# Altium schematic sheet Transductores_Presion.SchDoc(Transductores_Presion)
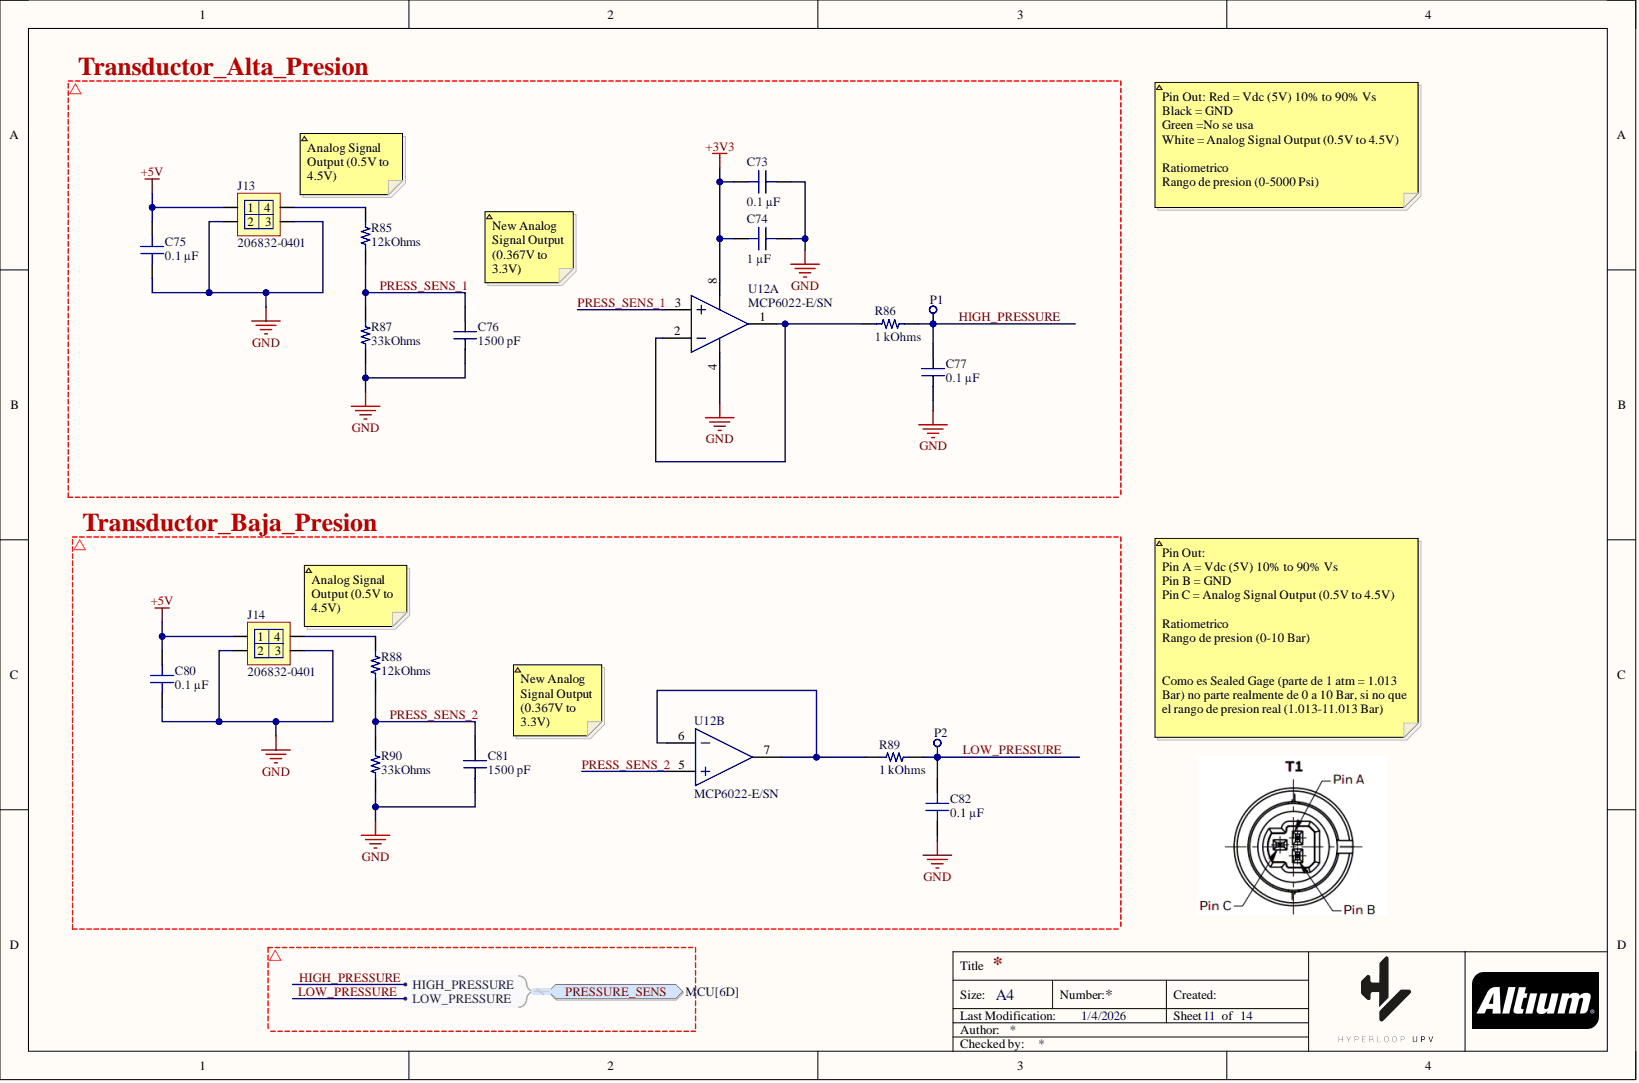
[diagram p0: Transductores_Presion.SchDoc]

## Parts
C73  0.1 µF
C74  1 µF
C75  0.1 µF
C76  1500 pF
C77  0.1 µF
C80  0.1 µF
C81  1500 pF
C82  0.1 µF
J13  206832-0401
J14  206832-0401
P1  Test point 1.2mm  (value not drawn)
P2  Test point 1.2mm  (value not drawn)
R85  12kOhms
R86  1 kOhms
R87  33kOhms
R88  12kOhms
R89  1 kOhms
R90  33kOhms
U12  MCP6022-E/SN  (parts A,B)

## Ports
PRESSURE_SENS, PRESSURE_SENS.HIGH_PRESSURE, PRESSURE_SENS.LOW_PRESSURE

## Nets
+3V3: C73.2 C74.1 U12.8
+5V: C75.2 C80.2 J13.1 J14.1
GND: C73.1 C74.2 C75.1 C76.1 C77.1 C80.1 C81.1 C82.1 J13.2 J13.3 J14.2 J14.3 R87.1 R90.1 U12.4
HIGH_PRESSURE: C77.2 P1.1 R86.2 ; port PRESSURE_SENS
LOW_PRESSURE: C82.2 P2.1 R89.2 ; port PRESSURE_SENS
PRESS_SENS_1: C76.2 R85.1 R87.2 U12.3
PRESS_SENS_2: C81.2 R88.1 R90.2 U12.5
NetJ13_4: J13.4 R85.2
NetJ14_4: J14.4 R88.2
NetR86_1: R86.1 U12.1 U12.2
NetR89_1: R89.1 U12.6 U12.7

## Library data of the parts
C73 C75 C80 = C0603X104K050T: CAP CER 0.1UF 50V X7R 0603; manufacturer: Holy Stone Enterprise Co., Ltd.; Database Table Name: Capacitors - Ceramic - 0603; Tolerance: ±10%; Applications: SMPS Filtering; Capacitance: 0.1 µF; Component Kind: Standard; componentlink1description: Datasheet; componentlink1url: https://www.holystonecaps.com/PDF/201612051329340.2017%20NCC%20Series.pdf; componentlink2description: DigiKey Link; componentlink2url: https://www.digikey.com/en/products/detail/holy-stone-enterprise-co-ltd/C0603X104K050T/16894915; Device Package: 0603 (1608 Metric); lastupdated: 2/9/2024 8:09:56 PM; Library Name: Celestial Altium Library - altium_library.DbLib; Lifecycle Status: Active; Minimum Order: 1; Mounting Type: Surface Mount, MLCC; Operating Temperature: -55°C ~ 125°C; Package / Case: 0603 (1608 Metric); packaging: TapeAndReel; Part Number: C0603X104K050T; Part Status: Active; partid: 35023; price: 0.01; series: MVC; Size / Dimension: 0.063" L x 0.031" W (1.60mm x 0.80mm); Supplier 1: DigiKey; Supplier Part Number 1: 4587-C0603X104K050TCT-ND; Temperature Coefficient: X7R; Thickness (Max): 0.039" (1.00mm); Value: 0.1 µF; Voltage - Rated: 50V
C74 = C1206C105K5RACAUTO: CAP CER 1UF 50V X7R 1206; manufacturer: KEMET; Database Table Name: Capacitors - Ceramic - 1206; Tolerance: ±10%; Applications: Automotive, Bypass, Decoupling; Capacitance: 1 µF; Component Kind: Standard; componentlink1description: Datasheet; componentlink1url: https://api.kemet.com/component-edge/download/datasheet/C1206C105K5RACAUTO.pdf; componentlink2description: DigiKey Link; componentlink2url: https://www.digikey.com/en/products/detail/kemet/C1206C105K5RACAUTO/3315003; Device Package: 1206 (3216 Metric); lastupdated: 6/4/2024 7:10:32 PM; Library Name: Celestial Altium Library - altium_library.DbLib; Lifecycle Status: Active; Minimum Order: 1; Mounting Type: Surface Mount, MLCC; Operating Temperature: -55°C ~ 125°C; Package / Case: 1206 (3216 Metric); packaging: TapeAndReel; Part Number: C1206C105K5RACAUTO; Part Status: Active; partid: 19104; price: 0.05; Ratings: AEC-Q200; series: SMD Auto X7R; Size / Dimension: 0.126" L x 0.063" W (3.20mm x 1.60mm); Supplier 1: DigiKey; Supplier Part Number 1: 399-7011-1-ND; Temperature Coefficient: X7R; Thickness (Max): 0.043" (1.10mm); Value: 1 µF; Voltage - Rated: 50V
C76 C81 = CGA3E2X7R1H152K080AA: CAP CER 1500PF 50V X7R 0603; manufacturer: TDK Corporation; Database Table Name: Capacitors - Ceramic - 0603; Tolerance: ±10%; Applications: Automotive; Capacitance: 1500 pF; Component Kind: Standard; componentlink1description: Datasheet; componentlink1url: https://product.tdk.com/info/en/catalog/datasheets/mlcc_automotive_general_en.pdf?ref_disty=digikey; componentlink2description: DigiKey Link; componentlink2url: https://www.digikey.com/en/products/detail/tdk-corporation/CGA3E2X7R1H152K080AA/2672813; Device Package: 0603 (1608 Metric); lastupdated: 2/9/2024 8:10:06 PM; Library Name: Celestial Altium Library - altium_library.DbLib; Lifecycle Status: Active; Minimum Order: 1; Mounting Type: Surface Mount, MLCC; Operating Temperature: -55°C ~ 125°C; Package / Case: 0603 (1608 Metric); packaging: TapeAndReel; Part Number: CGA3E2X7R1H152K080AA; Part Status: Active; partid: 17739; price: 0.01; Ratings: AEC-Q200; series: CGA; Size / Dimension: 0.063" L x 0.031" W (1.60mm x 0.80mm); Supplier 1: DigiKey; Supplier Part Number 1: 445-6920-1-ND; Temperature Coefficient: X7R; Thickness (Max): 0.035" (0.90mm); Value: 1500 pF; Voltage - Rated: 50V
C77 C82 = CGA3E2X7R1H104K080AE: CAP CER 0.1UF 50V X7R 0603; manufacturer: TDK Corporation; Database Table Name: Capacitors - Ceramic - 0603; Tolerance: ±10%; Applications: Automotive, Boardflex Sensitive; Capacitance: 0.1 µF; Component Kind: Standard; componentlink1description: Datasheet; componentlink1url: https://product.tdk.com/info/en/catalog/datasheets/mlcc_automotive_soft_en.pdf?ref_disty=digikey; componentlink2description: DigiKey Link; componentlink2url: https://www.digikey.com/en/products/detail/tdk-corporation/CGA3E2X7R1H104K080AE/4490594; Device Package: 0603 (1608 Metric); Features: Soft Termination; lastupdated: 2/9/2024 8:10:29 PM; Library Name: Celestial Altium Library - altium_library.DbLib; Lifecycle Status: Active; Minimum Order: 1; Mounting Type: Surface Mount, MLCC; Operating Temperature: -55°C ~ 125°C; Package / Case: 0603 (1608 Metric); packaging: TapeAndReel; Part Number: CGA3E2X7R1H104K080AE; Part Status: Active; partid: 2715; price: 0.01; Ratings: AEC-Q200; series: CGA; Size / Dimension: 0.063" L x 0.031" W (1.60mm x 0.80mm); Supplier 1: DigiKey; Supplier Part Number 1: 445-15957-1-ND; Temperature Coefficient: X7R; Thickness (Max): 0.037" (0.95mm); Value: 0.1 µF; Voltage - Rated: 50V
J13 J14 = CMP-006-00301-4: MICRO-FIT Plus Header Assembly Dual Row 4 Position Straight Tin; Connector Type: Micro-Fit+; Gender: Male; Max Current: 12.5 A; Max Voltage: 600V AC (RMS)/DC; Component Kind: Standard; Max Operating Temperature: 105°C; Min Operating Temperature: -40°C; Mounting Technology: THT; Pins: 4; RoHS Compliant: Yes; Library Name: Hyperloop UPV
P1 P2 = CMP-006-00250-2: 1.2mm Test point; Component Kind: Standard; Library Name: Hyperloop UPV
R85 R88 = RT0603BRD074K7L: RES SMD 4.7K OHM 0.1% 1/10W 0603; Manufacturer: Yageo; Database Table Name: Resistors - Surface Mount - 0603; Power (Watts): 0.1W, 1/10W; Resistance: 4.7 kOhms; Temperature Coefficient: ±25ppm/°C; Component Kind: Standard; ComponentLink1Description: Datasheet; ComponentLink1URL: https://www.yageo.com/upload/media/product/productsearch/datasheet/rchip/PYu-RT_1-to-0.01_RoHS_L_11.pdf; ComponentLink2Description: DigiKey Link; ComponentLink2URL: https://www.digikey.com/en/products/detail/yageo/RT0603BRD074K7L/1072561; Composition: Thin Film; Device Package: 0603 (1608 Metric); Height - Seated (Max): 0.022" (0.55mm); LastUpdated: 7/25/2024 9:19:29 AM; Library Name: Celestial Altium Library - altium_library.DbLib; Lifecycle Status: Active; Minimum Order: 1; Number of Terminations: 2; Operating Temperature: -55°C ~ 155°C; Package / Case: 0603 (1608 Metric); Packaging: TapeAndReel; Part Number: RT0603BRD074K7L; Part Status: Active; PartId: 10325; Price: 0.03; Series: RT; Size / Dimension: 0.063" L x 0.031" W (1.60mm x 0.80mm); Supplier 1: DigiKey; Supplier Device Package: 0603; Supplier Part Number 1: YAG1676CT-ND; Tolerance: ±0.1%; Value: 12kOhms
R86 R89 = RT0603FRE071KL: RES SMD 1K OHM 1% 1/10W 0603; Manufacturer: Yageo; Database Table Name: Resistors - Surface Mount - 0603; Power (Watts): 0.1W, 1/10W; Temperature Coefficient: ±50ppm/°C; Component Kind: Standard; ComponentLink1Description: Datasheet; ComponentLink1URL: https://www.yageo.com/upload/media/product/productsearch/datasheet/rchip/PYu-RT_1-to-0.01_RoHS_L_11.pdf; ComponentLink2Description: DigiKey Link; ComponentLink2URL: https://www.digikey.com/en/products/detail/yageo/RT0603FRE071KL/1075188; Composition: Thin Film; Device Package: 0603 (1608 Metric); Height - Seated (Max): 0.022" (0.55mm); LastUpdated: 7/25/2024 9:19:32 AM; Library Name: Celestial Altium Library - altium_library.DbLib; Lifecycle Status: Active; Minimum Order: 1; Number of Terminations: 2; Operating Temperature: -55°C ~ 155°C; Package / Case: 0603 (1608 Metric); Packaging: TapeAndReel; Part Number: RT0603FRE071KL; Part Status: Active; PartId: 5672; Price: 0.01; Resistance: 1 kOhms; Series: RT; Size / Dimension: 0.063" L x 0.031" W (1.60mm x 0.80mm); Supplier 1: DigiKey; Supplier Device Package: 0603; Supplier Part Number 1: YAG2323CT-ND; Tolerance: ±1%; Value: 1 kOhms
R87 R90 = RT0603BRD079K1L: RES SMD 9.1K OHM 0.1% 1/10W 0603; Manufacturer: Yageo; Database Table Name: Resistors - Surface Mount - 0603; Power (Watts): 0.1W, 1/10W; Resistance: 9.1 kOhms; Temperature Coefficient: ±25ppm/°C; Component Kind: Standard; ComponentLink1Description: Datasheet; ComponentLink1URL: https://www.yageo.com/upload/media/product/productsearch/datasheet/rchip/PYu-RT_1-to-0.01_RoHS_L_11.pdf; ComponentLink2Description: DigiKey Link; ComponentLink2URL: https://www.digikey.com/en/products/detail/yageo/RT0603BRD079K1L/1072692; Composition: Thin Film; Device Package: 0603 (1608 Metric); Height - Seated (Max): 0.022" (0.55mm); LastUpdated: 7/25/2024 9:19:29 AM; Library Name: Celestial Altium Library - altium_library.DbLib; Lifecycle Status: Active; Minimum Order: 1; Number of Terminations: 2; Operating Temperature: -55°C ~ 155°C; Package / Case: 0603 (1608 Metric); Packaging: TapeAndReel; Part Number: RT0603BRD079K1L; Part Status: Active; PartId: 10297; Price: 0.03; Series: RT; Size / Dimension: 0.063" L x 0.031" W (1.60mm x 0.80mm); Supplier 1: DigiKey; Supplier Device Package: 0603; Supplier Part Number 1: YAG1756CT-ND; Tolerance: ±0.1%; Value: 33kOhms
U12 = CMP-00005-4: Operational Amplifier, 2 Func, 2500uV Offset-Max, CMOS, PDSO8; Architecture: VOLTAGE-FEEDBACK; Average Bias Current-Max (IIB): 5nA; Common-mode Reject Ratio-Nom: 90dB; Frequency Compensation: YES; Input Offset Voltage-Max: 250uV; Low-Offset: No; Max Supply Current: 2.7mA; Number of Functions: 2; Package Body Material: Plastic; Package Equivalence Code: SOP8,.25; Power Supplies: 3/5V; Qualification Status: Not Qualified; Slew Rate: 7V/µs; Supply Voltage Limit-Max: 7V; Supply Voltage-Nom (Vsup): 5V; Time @ Peak Reflow Temperature-Max (s): 40s; Unity Gain BW-Nom: 10MHz; Voltage Gain-Min: 31620; Amplifier Type: OPERATIONAL AMPLIFIER; Component Kind: Standard; Height - Seated (Max): 1.75mm; JESD-30 Code: R-PDSO-G8; JESD-609 Code: e3; Length: 4.9mm; Low-Bias: Yes; Max Operating Temperature: 125°C; Micropower: No; Min Operating Temperature: -40°C; Moisture Sensitivity Level: 3; Number of Pins: 8; Package Code: SOP; Package Shape: Rectangular; Package Style: SMALL OUTLINEMeter; Packaging: Tape and Reel; Peak Reflow Temperature (Cel): 260°C; Power: No; Programmable Power: No; Reach SVHC Compliant: Yes; RoHS Compliant: Yes; Surface Mount: Yes; Technology: CMOS; Temperature Grade: AUTOMOTIVE; Terminal Finish: Tin; Terminal Form: Gull Wing; Terminal Pitch: 1.27mm; Terminal Position: DUAL; Wideband: No; Width: 3.91mm; Library Name: Hyperloop UPV
